# Altium schematic sheet XMC1404KEY4c.SchDoc(XMC1404KEY4c)
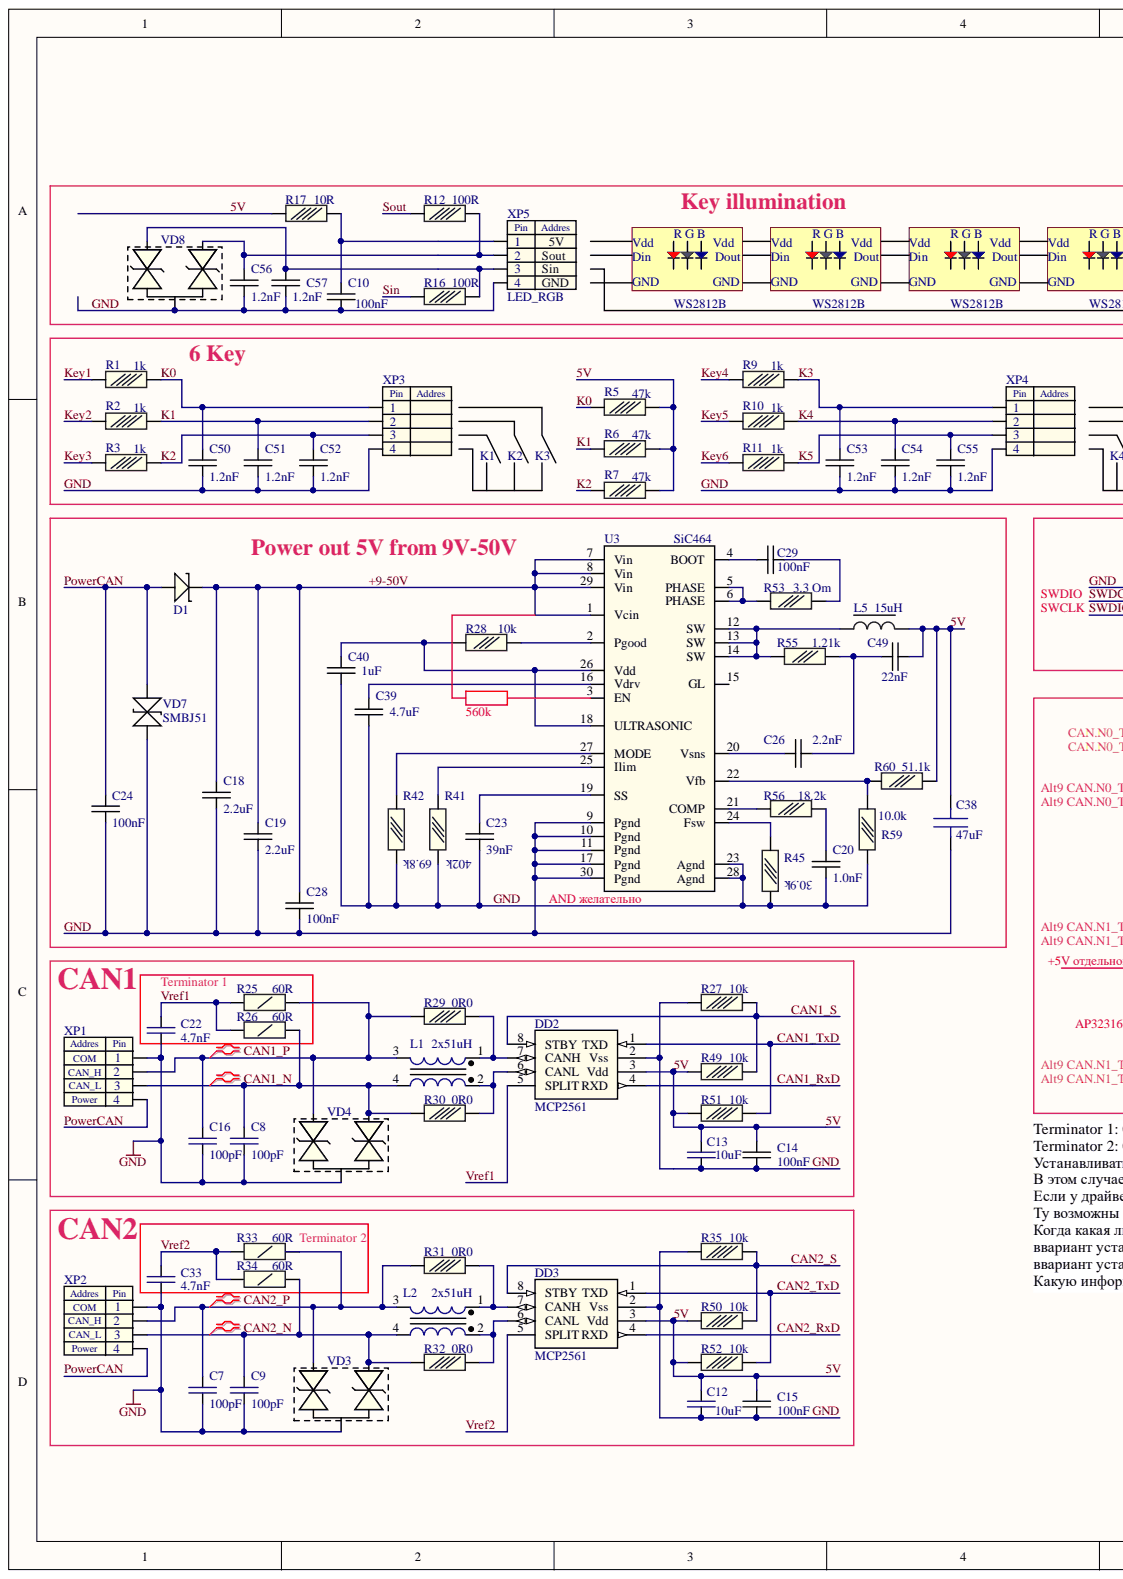
[diagram p0: XMC1404KEY4c.SchDoc, left]
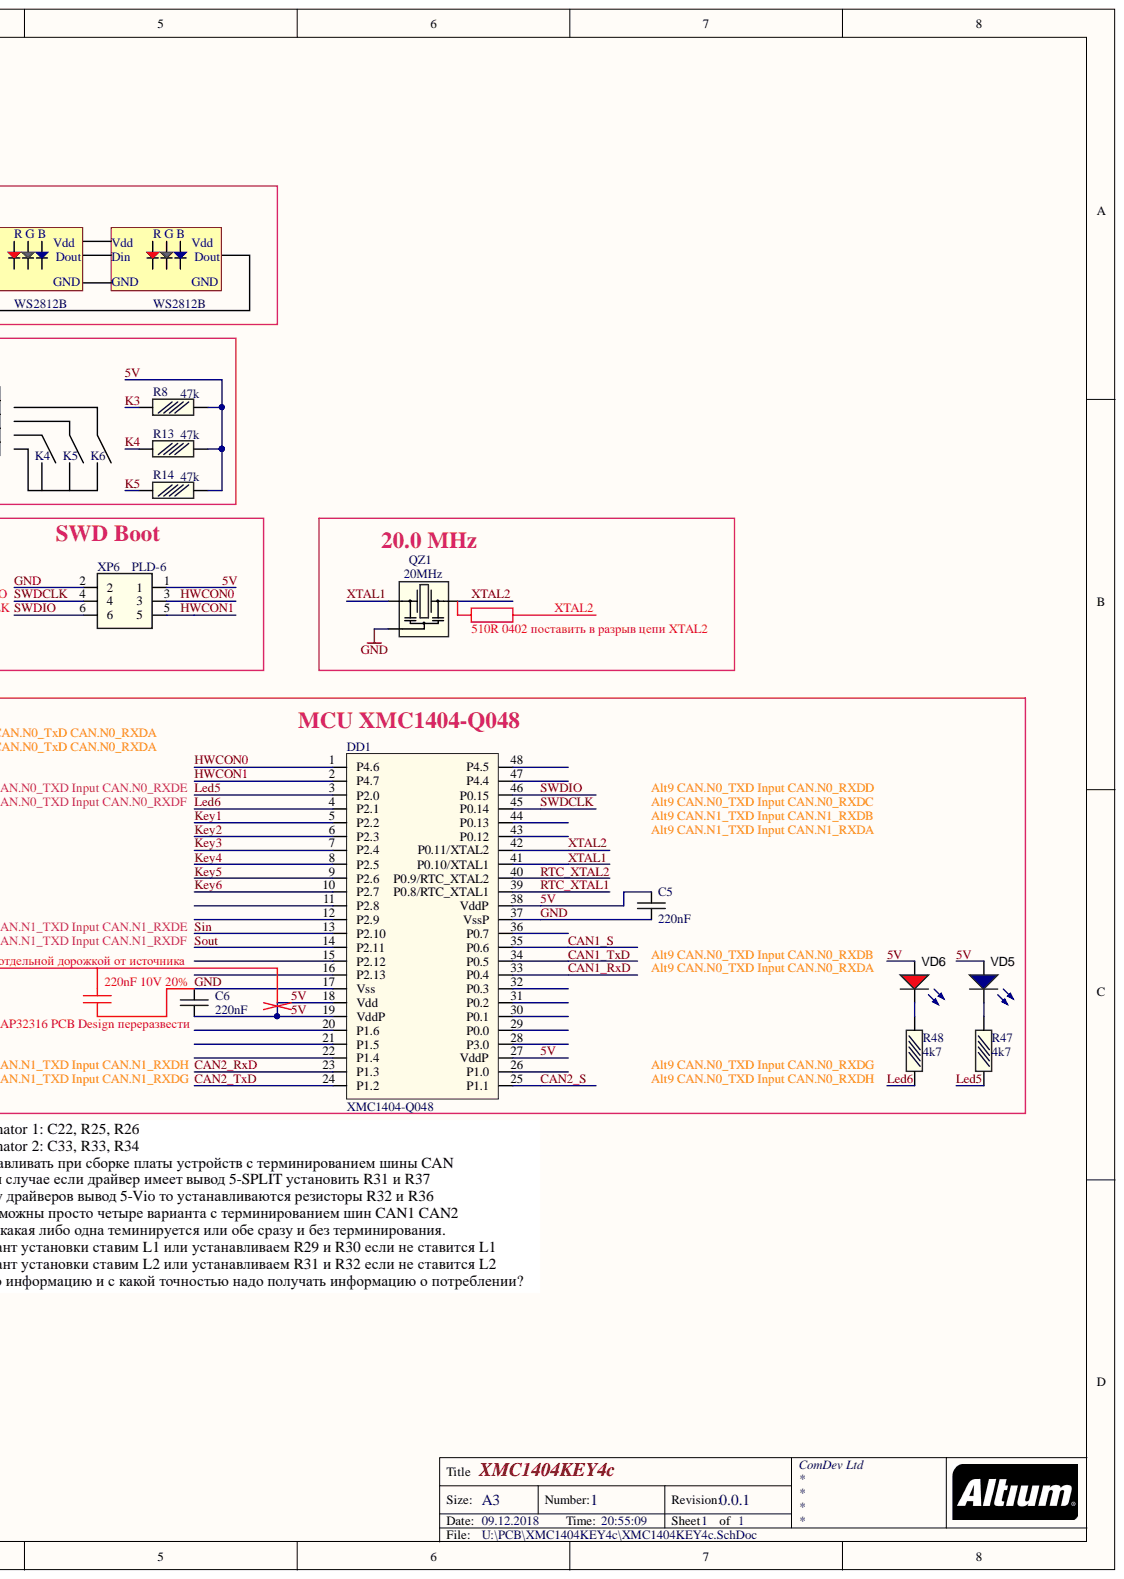
[diagram p1: XMC1404KEY4c.SchDoc, right]

## Parts
C5  220nF  [C0402]
C6  220nF  [C0402]
C7  100pF  [C0603]
C8  100pF  [C0603]
C9  100pF  [C0603]
C10  100nF  [C0402]
C12  10uF  [C0603]
C13  10uF  [C0603]
C14  100nF  [C0402]
C15  100nF  [C0402]
C16  100pF  [C0603]
C18  2.2uF  [C3225 EIA-CC1210]
C19  2.2uF  [C3225 EIA-CC1210]
C20  1.0nF  [C0603]
C22  4.7nF  [C0603]
C23  39nF  [C0603]
C24  100nF  [C0805]
C26  2.2nF  [C0603]
C28  100nF  [C0805]
C29  100nF  [C0603]
C33  4.7nF  [C0603]
C38  47uF  [1210_280]
C39  4.7uF  [C0603]
C40  1uF  [C0603]
C49  22nF  [C0603]
C50  1.2nF  [C0402]
C51  1.2nF  [C0402]
C52  1.2nF  [C0402]
C53  1.2nF  [C0402]
C54  1.2nF  [C0402]
C55  1.2nF  [C0402]
C56  1.2nF  [C0402]
C57  1.2nF  [C0402]
D1  MBR0580S1  [SOD-123 - MBR0520T]  (value not drawn)
DD1  XMC1404-Q048  [PG-VQFN-48-73-XMC1404-Q048]
DD2  MCP2561  [8DFN_MCP2561]
DD3  MCP2561  [8DFN_MCP2561]
L1  2x51uH  [WE-SLM-2x51]
L2  2x51uH  [WE-SLM-2x51]
L5  15uH  [IHLP3232DZER150M11]
QZ1  20MHz  [CSTNE20M0V53C000R0]
R1  1k  [R0402]
R2  1k  [R0402]
R3  1k  [R0402]
R5  47k  [R0402]
R6  47k  [R0402]
R7  47k  [R0402]
R8  47k  [R0402]
R9  1k  [R0402]
R10  1k  [R0402]
R11  1k  [R0402]
R12  100R  [R0402]
R13  47k  [R0402]
R14  47k  [R0402]
R16  100R  [R0402]
R17  10R  [R0402]
R25  60R  [1206]
R26  60R  [1206]
R27  10k  [R0402]
R28  10k  [R0603H-103]
R29  0R0  [R0402]
R30  0R0  [R0402]
R31  0R0  [R0402]
R32  0R0  [R0402]
R33  60R  [1206]
R34  60R  [1206]
R35  10k  [R0402]
R41  402k  [R0603H-470]
R42  69.8k  [R0603H-683]
R45  30.9k  [R0603H-2612]
R47  4k7  [R0402]
R48  4k7  [R0402]
R49  10k  [R0402]
R50  10k  [R0402]
R51  10k  [R0402]
R52  10k  [R0402]
R53  3.3 Om  [R0603H-104]
R55  1.21k  [R0603H-2612]
R56  18.2k  [R0603H-2612]
R59  10.0k  [R0603H-5622]
R60  51.1k  [R0603H-5622]
U3  SiC464  [SiC464_MLP55-27L]
VD3  NUP2105L  [SOT-23]  (value not drawn)
VD4  NUP2105L  [SOT-23]  (value not drawn)
VD5  Blue  [Led0603Blue]  (value not drawn)
VD6  Red  [Led0603Red]  (value not drawn)
VD7  SMBJ51  [SMBJ51-DO-214AA]
VD8  NUP2105L  [SOT-23]  (value not drawn)
XP1  PTSM 0,5/ 4-2,5-V THR R44  [PTSM 0,5/ 4-2,5-H THR R32]  (value not drawn)
XP2  PTSM 0,5/ 4-2,5-V THR R44  [PTSM 0,5/ 4-2,5-H THR R32]  (value not drawn)
XP3  PTSM 0,5/ 4-2,5-V THR R44  [PTSM 0,5/ 4-2,5-H THR R32]  (value not drawn)
XP4  PTSM 0,5/ 4-2,5-V THR R44  [PTSM 0,5/ 4-2,5-H THR R32]  (value not drawn)
XP5  PTSM 0,5/ 4-2,5-V THR R44  [PTSM 0,5/ 4-2,5-H THR R32]  (value not drawn)
XP6  PLD-6  [PBD1.27-6-smd]

## Nets
5V: C5.2 C6.1 C12.2 C13.2 C14.2 C15.2 C38.1 C49.1 DD1.18(Vdd) DD1.19(VddP) DD1.27(VddP) DD1.38(VddP) DD2.3(Vdd) DD3.3(Vdd) L5.2 R5.1 R6.1 R7.1 R8.1 R13.1 R14.1 R17.2 R49.1 R50.1 R51.1 R52.1 R60.2 VD5.1 VD6.1 XP6.1
+9-50V: C18.1 C19.1 C28.2 D1.1 U3.1(Vcin) U3.7(Vin) U3.8(Vin) U3.29(Vin)
CAN1_N: C8.2 L1.4 R26.1 R30.1 VD4.2 XP1.3
CAN1_P: C16.2 L1.3 R25.1 R29.1 VD4.1 XP1.2
CAN1_RxD: DD1.33(P0.4) DD2.4(RXD)
CAN1_S: DD1.35(P0.6) DD2.8(STBY) R27.2 R49.2
CAN1_TxD: DD1.34(P0.5) DD2.1(TXD) R51.2
CAN2_N: C9.2 L2.4 R32.1 R34.1 VD3.2 XP2.3
CAN2_P: C7.2 L2.3 R31.1 R33.1 VD3.1 XP2.2
CAN2_RxD: DD1.23(P1.3) DD3.4(RXD)
CAN2_S: DD1.25(P1.1) DD3.8(STBY) R35.2 R50.2
CAN2_TxD: DD1.24(P1.2) DD3.1(TXD) R52.2
GND: C5.1 C6.2 C7.1 C8.1 C9.1 C10.1 C12.1 C13.1 C14.1 C15.1 C16.1 C18.2 C19.2 C20.2 C22.1 C23.1 C24.1 C28.1 C33.1 C38.2 C39.2 C40.2 C50.1 C51.1 C52.1 C53.1 C54.1 C55.1 C56.1 C57.1 DD1.17(Vss) DD1.37(VssP) DD2.2(Vss) DD3.2(Vss) QZ1.2 R27.1 R35.1 R41.1 R42.1 R45.1 R59.2 U3.9(Pgnd) U3.10(Pgnd) U3.11(Pgnd) U3.17(Pgnd) U3.23(Agnd) U3.28(Agnd) U3.30(Pgnd) VD3.3 VD4.3 VD7.1 VD8.3 XP1.1 XP2.1 XP3.4 XP4.4 XP5.4 XP6.2
HWCON0: DD1.1(P4.6) XP6.3
HWCON1: DD1.2(P4.7) XP6.5
K0: C50.2 R1.1 R5.2 XP3.1
K1: C51.2 R2.1 R6.2 XP3.2
K2: C52.2 R3.1 R7.2 XP3.3
K3: C53.2 R8.2 R9.1 XP4.1
K4: C54.2 R10.1 R13.2 XP4.2
K5: C55.2 R11.1 R14.2 XP4.3
Key1: DD1.5(P2.2) R1.2
Key2: DD1.6(P2.3) R2.2
Key3: DD1.7(P2.4) R3.2
Key4: DD1.8(P2.5) R9.2
Key5: DD1.9(P2.6) R10.2
Key6: DD1.10(P2.7) R11.2
Led5: DD1.3(P2.0) R47.1
Led6: DD1.4(P2.1) R48.1
PowerCAN: C24.2 D1.2 VD7.2 XP1.4 XP2.4
RTC_XTAL1: DD1.39(P0.8/RTC_XTAL1)
RTC_XTAL2: DD1.40(P0.9/RTC_XTAL2)
Sin: DD1.13(P2.10) R16.2
Sout: DD1.14(P2.11) R12.2
SWDCLK: DD1.45(P0.14) XP6.4
SWDIO: DD1.46(P0.15) XP6.6
Vref1: C22.2 DD2.5(SPLIT) R25.2 R26.2
Vref2: C33.2 DD3.5(SPLIT) R33.2 R34.2
XTAL1: DD1.41(P0.10/XTAL1) QZ1.1
XTAL2: DD1.42(P0.11/XTAL2) QZ1.3
NetC10_2: C10.2 R17.1 XP5.1
NetC20_1: C20.1 R56.1
NetC23_2: C23.2 U3.19(SS)
NetC26_1: C26.1 C49.2 R55.1
NetC26_2: C26.2 U3.20(Vsns)
NetC29_1: C29.1 R53.2
NetC29_2: C29.2 U3.4(BOOT)
NetC39_1: C39.1 U3.16(Vdrv)
NetC40_1: C40.1 R28.1 U3.18(ULTRASONIC) U3.26(Vdd)
NetC56_2: C56.2 R12.1 VD8.2 XP5.2
NetC57_2: C57.2 R16.1 VD8.1 XP5.3
NetDD2_6: DD2.6(CANL) L1.2 R30.2
NetDD2_7: DD2.7(CANH) L1.1 R29.2
NetDD3_6: DD3.6(CANL) L2.2 R32.2
NetDD3_7: DD3.7(CANH) L2.1 R31.2
NetL5_1: L5.1 R55.2 U3.12(SW) U3.13(SW) U3.14(SW)
NetR28_2: R28.2 U3.2(Pgood)
NetR41_2: R41.2 U3.25(Ilim)
NetR42_2: R42.2 U3.27(MODE)
NetR45_2: R45.2 U3.24(Fsw)
NetR47_2: R47.2 VD5.2
NetR48_2: R48.2 VD6.2
NetR53_1: R53.1 U3.5(PHASE) U3.6(PHASE)
NetR56_2: R56.2 U3.21(COMP)
NetR59_1: R59.1 R60.1 U3.22(Vfb)

Not connected: DD1 11(P2.8) 12(P2.9) 15(P2.12) 16(P2.13) 20(P1.6) 21(P1.5) 22(P1.4) 26(P1.0) 28(P3.0) 29(P0.0) 30(P0.1) 31(P0.2) 32(P0.3) 36(P0.7) 43(P0.12) 44(P0.13) 47(P4.4) 48(P4.5); U3 3(EN) 15(GL)

## Library data of the parts
C5 C6 = C0402: 220nF 6.3V 10% 0603 X7R; L_DB: ???; ValueSCH: 220nF; NoteSCH: X7R; Library Name: Capacitors.SchLib; ComponentLink2Description: Datasheet; Component Kind: Standard; ComponentLink2URL: C:\Project\Doc\Конденсаторы\Керамика\MLCC__Chip__X7R.pdf
C7-C9 C16 = C0603: CAP CER 100PF 25V X7R 0603; Manufacturer Part Number: 06033C101KAT2A; Manufacturer Name: AVX Corporation; Value: 100pF/25V/X7R/10%/SMD/0603; Tolerance: ±10%; Voltage - Rated: 25V; ValueSch: 100pF; Thickness (Max): 0.035" (0.90mm); Temperature Coefficient: X7R; Supplier Part Number 1: 478-7899-2-ND; Supplier 1: Digi-Key; Stock 1: 24000; Size / Dimension: 0.063" L x 0.032" W (1.60mm x 0.81mm); RoHS: RoHS Compliant; Ratings: -; Pricing 1: 4000=0.0418, 8000=0.03971, 12000=0.03762 (USD); Part Status: Active; Packaging: Tape & Reel (TR); Package / Case: 0603 (1608 Metric); Operating Temperature: -55°C ~ 125°C; Mounting Type: Surface Mount, MLCC; Library Name: Capacitors.SchLib; Lead Style: -; Lead Spacing: -; Height - Seated (Max): -; Features: -; Failure Rate: -; ComponentLink3URL: http://datasheets.avx.com/X7RDielectric.pdf; ComponentLink3Description: Datasheet1; ComponentLink2URL: http://analytics.supplyframe.com/trackingservlet/track/?r=gPVv3S5fz9WS0muSSENj7lwoQ-bwq6U_kTVAS-E-4hFfgjTGNLzICkif58pW5xVzodrlN_TFWDfT-ihNJt1bYujWQ1ervE1ODJn9hr95yS1WEK84krV-DVCh3Pjis-mDRI3V01RDXCSfn3TgClWxILI83iyMk702gQDWEiRNL7hjlIlwe7usE8k12gPZrRSiqHgTvvD3EcVIugmCVY18oj7b5cljMpSJ7IWvPMjQLzsYV9TI6TLqAWqhYn857NWra7I7WIt4LPWFkkh1MoSB8QsQPueJ7cWwSbt4t62NzL017uztGtoLOf0FGsKGNBWESZWOcdJ6202ZSChtzbyJ3aCGV0V0vDtxPirZU9HbAKCtZHougte4xZ9MMqby1oitbLO64CniNyiwkJNtVPIyrynnG8uFkhA482lKC76bORkhaPKnCl4U_69JWakdAr7PCLCuSBhWj0c3rGkDodRwls4EObJQnz4gUzrAOT7V_rgoM_Io8BWJQSzhMwh6iWlDz_NNcRo2wvNcatip_pJJ4vSL8TnRa_NPsW0ZvwBjV9dIyk2oNY8-7e27U9VPQ8CszMTe1mfDoxXcIQrWsehP0w; ComponentLink2Description: Datasheet; ComponentLink1URL: https://www.arrow.com/en/manufacturers/tdk; ComponentLink1Description: Manufacturer Link; Component Kind: Standard; Category: Capacitors; Applications: General Purpose
C10 C14 C15 = C0402: 100nF 10V 10% 0603 X7R; L_DB: ???; ValueSCH: 100nF; NoteSCH: X7R; Library Name: Capacitors.SchLib; ComponentLink2Description: Datasheet; Component Kind: Standard; ComponentLink2URL: C:\Project\Doc\Конденсаторы\Керамика\MLCC__Chip__X7R.pdf
C12 C13 = C0603: CAP CER 10UF 6.3V X5R 0603; Manufacturer Part Number: CC0603MRX5R5BB106; Manufacturer Name: Yageo; Value: 10uF/6.3V/20%/X5R/C0603; Tolerance: ±20%; Voltage - Rated: 6.3V; ValueSCH: 10uF; Thickness (Max): 0.035" (0.90mm); Temperature Coefficient: X5R; Supplier Part Number 1: 399-5504-2-ND; Supplier 1: Digi-Key; Stock 1: 1768000; Size / Dimension: 0.063" L x 0.032" W (1.60mm x 0.80mm); RoHS: RoHS Compliant; Ratings: -; Pricing 1: 4000=0.08041, 8000=0.07568, 12000=0.07332 (USD); Part Status: Active; Packaging: Tape & Reel (TR); Package / Case: 0603 (1608 Metric); Operating Temperature: -55°C ~ 85°C; Mounting Type: Surface Mount, MLCC; Library Name: Capacitors.SchLib; Lead Style: -; Lead Spacing: -; Height - Seated (Max): -; Features: -; Failure Rate: -; ComponentLink2URL: http://www.kemet.com/docfinder?Partnumber=C0603C106M9PACTU; ComponentLink2Description: Link; ComponentLink1URL: http://datasheets.avx.com/Y5V.pdf; ComponentLink1Description: Datasheet; Component Kind: Standard; Category: Capacitors; Applications: General Purpose
C18 C19 = C1210: 2.2uF 1210 X7R 100V; Part Number: C1210S225M1RA; L_DB: ???; ValueSCH: 2.2uF; Value: 2.2uF 1210 X7R 100V; Library Name: Capacitors.SchLib; ComponentLink2Description: Datasheet; Component Kind: Standard; ComponentLink2URL: C:\Project\Doc\Конденсаторы\Керамика\MLCC__Chip__X7R.pdf
C20 = C0603: CAP CER 1.0nF 50V X7R 0603 1.0 mOhm; Manufacturer Name: GRM188R71H102KA01D; Value: CAP CER 2.0nF 50V X7R 0603 1.0 mOhm; ValueSch: 1.0nF; Library Name: Capacitors.SchLib; ComponentLink2Description: Datasheet; ComponentLink1Description: Manufacturer Link; Component Kind: Standard
C22 C33 = C0603: CAP CER 4700PF 50V C0G 0603; Manufacturer Part Number: CGA3E2C0G1H472J080AA; Manufacturer Name: TDK; Manufacturer: TDK Corporation; Tolerance: ±5%; Temperature Coefficient: C0G, NP0; Voltage - Rated: 50V; ValueSch: 4.7nF; Value: CAP CER 4700PF 50V C0G 0603; Thickness (Max): 0.035" (0.90mm); Supplier Part Number 1: 445-6912-2-ND; Supplier 1: Digi-Key; Stock 1: 4000; Size / Dimension: 0.063" L x 0.032" W (1.60mm x 0.80mm); RoHS: RoHS Compliant; Ratings: AEC-Q200; Pricing 1: 4000=0.05088, 8000=0.04813, 12000=0.04565 (USD); Part Status: Active; Packaging: Tape & Reel (TR); Package / Case: 0603 (1608 Metric); Operating Temperature: -55°C ~ 125°C; Mounting Type: Surface Mount, MLCC; Library Name: Capacitors.SchLib; ComponentLink3URL: https://product.tdk.com/info/en/catalog/datasheets/mlcc_automotive_general_en.pdf; ComponentLink3Description: Datasheet2; ComponentLink2URL: https://www.arrow.com/en/manufacturers/tdk; ComponentLink2Description: Datasheet1; Component Kind: Standard; Category: Capacitors; Applications: Automotive
C23 = C0603: 39nF 50V COG 0603; Value: 39nF 50V COG 0603 +-0.1pF; ValueSch: 39nF; Library Name: Capacitors.SchLib; ComponentLink2Description: Datasheet; ComponentLink1Description: Manufacturer Link; Component Kind: Standard
C24 C28 = C0805: 100nF X7R 100V 0805 20%; Part Nomber: VJ0805Y104MXB; L_DB: ???; ValueSCH: 100nF; Value: 100nF X7R 100V 0805 20%; PCB3D: 0805; NoteSCH: X7R; Library Name: Capacitors.SchLib; ComponentLink2Description: Datasheet; Component Kind: Standard; ComponentLink2URL: C:\Project\Doc\Конденсаторы\Керамика\MLCC__Chip__X7R.pdf
C26 = C0603: CAP CER 2.2nF 50V X7R 0603 1.0 mOhm; Manufacturer Name: GRM188R71H222KA01D; ValueSch: 2.2nF; Value: CAP CER 2.2nF 50V X7R 0603 1.0 mOhm; Library Name: Capacitors.SchLib; ComponentLink2Description: Datasheet; ComponentLink1Description: Manufacturer Link; Component Kind: Standard
C29 = C0603: 0.1uF 25V BX 10% 0603 Ceramic; Manufacturer Part Number: 03028-BX104ZKZ; ValueSch: 100nF; Value: 0.1uF 25V BX 10% 0603 Ceramic; Library Name: Capacitors.SchLib; ComponentLink2Description: Datasheet; ComponentLink1Description: Manufacturer Link; Component Kind: Standard
C38 = Cout_12V: CAP CER 47UF 16V X5R 1210; Manufacturer Part Number: GRM32ER61C476ME15L; Manufacturer Name: MuRata; Manufacturer: Murata Electronics North America; Tolerance: ±20%; Voltage - Rated: 16V; ValueSCH: 47uF; Value: CAP CER 47UF 16V X5R 1210; Thickness (Max): 0.106" (2.70mm); Temperature Coefficient: X5R; Supplier Part Number 2: 490-8355-2-ND; Supplier Part Number 1: 490-3888-2-ND; Supplier 2: Digi-Key; Supplier 1: Digi-Key; Stock 2: 60000; Stock 1: 418000; Size / Dimension: 0.126" L x 0.098" W (3.20mm x 2.50mm); RoHS: RoHS Compliant; Ratings: -; Pricing 2: 4000=0.231, 8000=0.22275, 12000=0.21945 (USD); Pricing 1: 1000=0.264, 2000=0.2475 (USD); Part Status: Active; Packaging: Tape & Reel (TR); PackageReference: GRM32ER61C476ME15L; Package / Case: 1210 (3225 Metric); Operating Temperature: -55°C ~ 85°C; Mounting Type: Surface Mount, MLCC; Lead Style: -; Lead Spacing: -; Height - Seated (Max): -; Features: -; ComponentLink1URL: http://www.murata.com/~/media/webrenewal/support/library/catalog/products/capacitor/mlcc/c02e.pdf; ComponentLink1Description: Datasheet; Component Kind: Standard; Category: Capacitors; Applications: General Purpose; Library Name: Vin50Vout12V_2A.SCHLIB
C39 = C0603: 4.7uF 20% X5R 16V 0603; Part Nomber: VJ0603G475MXJ; ValueSch: 4.7uF; Value: 4.7uF 20% X5R 16V 0603; Library Name: Capacitors.SchLib; ComponentLink2Description: Datasheet; ComponentLink1Description: Manufacturer Link; Component Kind: Standard
C40 = C0603: 1UF 20% 0603 Y5V 16V; Manufacturer Part Number: VJ0603V105MXA; Value: 1UF 20% 0603 Y5V 16V Ceramic; ValueSch: 1uF; Library Name: Capacitors.SchLib; ComponentLink2Description: Datasheet; ComponentLink1Description: Manufacturer Link; Component Kind: Standard
C49 = C0603: CAP CER 22nF 50V X7R 0603 1.0 mOhm; Manufacturer Name: GRM188R71H202KA01D; ValueSch: 22nF; Value: CAP CER 22nF 50V X7R 0603 1.0 mOhm; Library Name: Capacitors.SchLib; ComponentLink2Description: Datasheet; ComponentLink1Description: Manufacturer Link; Component Kind: Standard
C50-C57 = C0402: Value: 1.2nF 0402 20% COG; NoteSCH: COG; L_DB: ???; ValueSCH: 1.2nF; Library Name: Capacitors.SchLib; ComponentLink2Description: Datasheet; Component Kind: Standard; ComponentLink2URL: C:\Project\Doc\Конденсаторы\Керамика\MLCC__Chip__X7R.pdf
D1 = MBR0580S1: Диод SMD MBR0580S1 DIODS; L_DB: ???; ValueSCH: MBR0580S1; Library Name: Diodes.SchLib; Component Kind: Standard
DD1 = XMC1404-Q048: XMC1404-Q048X0200; Flash Size: 200k; Value_SCH: XMC1404-Q048; Library Name: MCU.SchLib; Device Type: XMC1404-Q048X0200; ComponentLink2Description: Datasheet; Component Kind: Standard; ComponentLink2URL: C:\Project\Doc\Maxim\HART\DS8500.pdf
DD2 DD3 = MCP2561: High speed CAN transceiver with SPLIT and 5V microcontroller interface; Library Name: Driver.SchLib; Component Kind: Standard
L1 L2 = WE-SLM-2x51: SMD Common Mode Line Filter; L_DB: ???; ValueSCH: 2x51uH; Library Name: Inductor.SchLib; ComponentLink2Description: Datasheet; Component Kind: Standard; ComponentLink2URL: C:\Project\Doc\Индуктивности\SMLP5001.pdf
L5 = IHLP3232DZER150M11: Rdcmax: 65.3mOhm; Rdc: 61.0mOhm; L_DB: ???; ValueSCH: 15uH; NoteSCH: 15uH; Library Name: Inductor.SchLib; Description: IHLP3232DZER150M11; ComponentLink2Description: Datasheet; Component Kind: Standard; ComponentLink2URL: C:\Project\Doc\Индуктивности\slf6028.pdf
QZ1 = CSTNE20M0V53C000R0: CS TNE 20.00MHz Built in Caps 15 pF; Value: 20.00MHz 0.15% with C 15pF; NoteSCH: CSTNE; Mark: Not Mark; L_DB: ???; ValueSCH: 20MHz; Package: ECS; ComponentLink2Description: Datasheet; Component Kind: Standard; Library Name: Crystal Units.SchLib; ComponentLink2URL: C:\Project\Doc\Кварцевые резонаторы\KX-327XS.pdf
R1-R3 R9-R11 = R0402H: 1k 5% R0402; ValueSCH: 1k; Value: 1k 5% R0402; PCB3D: R0402H; Library Name: Resistors.SchLib; Component Kind: Standard
R5-R8 R13 R14 = R0402H: 47k 5% R0402; ValueSCH: 47k; Value: 47k 5% R0402; PCB3D: R0402H; Library Name: Resistors.SchLib; Component Kind: Standard
R12 R16 = R0402H: 100R 5% R0402; ValueSCH: 100R; Value: 100R 5% R0402; PCB3D: R0402H; Library Name: Resistors.SchLib; Component Kind: Standard
R17 = R0402H: 10R 5% R0402; ValueSCH: 10R; Value: 10R 5% R0402; PCB3D: R0402H; Library Name: Resistors.SchLib; Component Kind: Standard
R25 R26 R33 R34 = R1206: RES SMD 60 OHM 5% 1/2W 1206; Value: 60R/5%/1206/0.5W; L_DB: ???; ValueSCH: 60R; PCB3D: 1206; Library Name: Resistors.SchLib; ComponentLink2Description: Datasheet; Component Kind: Standard; ComponentLink2URL: C:\Project\Doc\Резисторы\Pu-RC1206_51_PbFree_L_2-1.pdf
R27 R35 R49-R52 = R0402H: RES SMD 10KOHM 5% 1/16W 0402; Value: 10k/5%/0402/0.125W; ValueSCH: 10k; PCB3D: R0402H; Library Name: Resistors.SchLib; Component Kind: Standard
R28 = R0603: RES SMD 10K OHM 5% 1/4W 0603; Manufacturer Part Number: RC0603JR-07103RL; Manufacturer Name: Yageo; Value: 10k/5%/0603/0.25W; L_DB: ???; ValueSCH: 10k; Library Name: Resistors.SchLib; ComponentLink2URL: https://www.digikey.com/product-detail/en/yageo/RC0603JR-074K7L/311-4.7KGRTR-ND/726785; ComponentLink2Description: Datasheet; ComponentLink1URL: https://www.digikey.com/en/supplier-centers/y/yageo; ComponentLink1Description: Manufacturer Link; Component Kind: Standard
R29-R32 = R0402H: RES SMD 0 OHM 5% 1/16W 0402; Value: 0R0/5%/0402/0.125W; ValueSCH: 0R0; PCB3D: R0402H; Library Name: Resistors.SchLib; Component Kind: Standard
R41 = R0603: RES SMD 402k 1% 1/10W 0603; Manufacturer Part Number: CMF55603K00BEEA70; Manufacturer Name: Yageo; Manufacturer: Vishay Dale; Velue: 402k/1%/0.1W/0603; Temperature Coefficient: ±100ppm/°C; Power (Watts): 0.125W, 1/10W; L_DB: ???; ValueSCH: 402k; Tolerance: ±1%; Supplier Part Number 1: CMF55603K00BEEA70; Supplier Device Package: 0603; Supplier 1: Digi-Key; Stock 1: 0; Size / Dimension: 0.039" L x 0.020" W (1.00mm x 0.50mm); RoHS: RoHS Compliant; Pricing 1: 10000=0.0038, 30000=0.00334, 50000=0.00306, 100000=0.003 (USD); Part Status: Active; Packaging: Tape & Reel (TR); Package / Case: 0603; Operating Temperature: -55°C ~ 155°C; Library Name: Resistors.SchLib; Height - Seated (Max): 0.016" (0.40mm); Features: Automotive AEC-Q200; Failure Rate: -; Composition: Thick Film; ComponentLink3URL: http://www.vishay.com/docs/20035/dcrcwe3.pdf; ComponentLink3Description: http://www.vishay.com/docs/20035/dcrcwe3.pdf; ComponentLink2URL: https://www.digikey.com/product-detail/en/yageo/RC0603FR-0726K1L/311-26.1KHRTR-ND/727092; ComponentLink2Description: Datasheet; ComponentLink1URL: https://www.digikey.com/en/supplier-centers/y/yageo; ComponentLink1Description: Manufacturer Link; Component Kind: Standard; Category: Resistors
R42 = R0603: RES SMD 69.8k 1% 1/10W 0603; Manufacturer Part Number: CCF-50 6982 F T1 R36; Manufacturer Name: Yageo; Manufacturer: Vishay Dale; Velue: 69.8k/1%/0.1W/0603; Temperature Coefficient: ±100ppm/°C; Power (Watts): 0.125W, 1/10W; L_DB: ???; ValueSCH: 69.8k; Tolerance: ±1%; Supplier Part Number 1: 541-26.1KLTR-ND; Supplier Device Package: 0603; Supplier 1: Digi-Key; Stock 1: 0; Size / Dimension: 0.039" L x 0.020" W (1.00mm x 0.50mm); RoHS: RoHS Compliant; Pricing 1: 10000=0.0038, 30000=0.00334, 50000=0.00306, 100000=0.003 (USD); Part Status: Active; Packaging: Tape & Reel (TR); Package / Case: 0603; Operating Temperature: -55°C ~ 155°C; Library Name: Resistors.SchLib; Height - Seated (Max): 0.016" (0.40mm); Features: Automotive AEC-Q200; Failure Rate: -; Composition: Thick Film; ComponentLink3URL: http://www.vishay.com/docs/20035/dcrcwe3.pdf; ComponentLink3Description: http://www.vishay.com/docs/20035/dcrcwe3.pdf; ComponentLink2URL: https://www.digikey.com/product-detail/en/yageo/RC0603FR-0769K8L/311-69.8KHRTR-ND/727092; ComponentLink2Description: Datasheet; ComponentLink1URL: https://www.digikey.com/en/supplier-centers/y/yageo; ComponentLink1Description: Manufacturer Link; Component Kind: Standard; Category: Resistors
R45 = R0603: RES SMD 30.9kOHM 1% 1/10W 0603; Manufacturer Part Number: CRCW06033094RFKED; Manufacturer Name: Yageo; Manufacturer: Vishay Dale; Velue: 30.9k/1%/0.1W/0603; Temperature Coefficient: ±100ppm/°C; Power (Watts): 0.125W, 1/10W; L_DB: ???; ValueSCH: 30.9k; Tolerance: ±1%; Supplier Part Number 1: 541-30.9KLTR-ND; Supplier Device Package: 0603; Supplier 1: Digi-Key; Stock 1: 0; Size / Dimension: 0.039" L x 0.020" W (1.00mm x 0.50mm); RoHS: RoHS Compliant; Pricing 1: 10000=0.0038, 30000=0.00334, 50000=0.00306, 100000=0.003 (USD); Part Status: Active; Packaging: Tape & Reel (TR); Package / Case: 0603; Operating Temperature: -55°C ~ 155°C; Library Name: Resistors.SchLib; Height - Seated (Max): 0.016" (0.40mm); Features: Automotive AEC-Q200; Failure Rate: -; Composition: Thick Film; ComponentLink3URL: http://www.vishay.com/docs/20035/dcrcwe3.pdf; ComponentLink3Description: http://www.vishay.com/docs/20035/dcrcwe3.pdf; ComponentLink2URL: https://www.digikey.com/product-detail/en/yageo/RC0603FR-0744K2L/311-44.2KHRTR-ND/727092; ComponentLink2Description: Datasheet; ComponentLink1URL: https://www.digikey.com/en/supplier-centers/y/yageo; ComponentLink1Description: Manufacturer Link; Component Kind: Standard; Category: Resistors
R47 R48 = R0402H: RES SMD 4.7KOHM 5% 1/16W 0402; Value: 4k7/5%/0402/0.125W; ValueSCH: 4k7; PCB3D: R0402H; Library Name: Resistors.SchLib; Component Kind: Standard
R53 = R0603: RES SMD 3.3 OHM 5% 1/10W 0603; Value: 3.3Om/5%/0603/0.125W; L_DB: ???; ValueSCH: 3.3 Om; NoteSCH: RES SMD 3.3 OHM 5% 1/10W 0603; Library Name: Resistors.SchLib; ComponentLink2Description: Datasheet; ComponentLink1Description: Manufacturer Link; Component Kind: Standard
R55 = R0603: RES SMD 1.21kOHM 1% 1/10W 0603; Manufacturer Part Number: CRCW06031.21kRFKED; Manufacturer Name: Yageo; Manufacturer: Vishay Dale; Velue: 1.21k/1%/0.1W/0603; Temperature Coefficient: ±100ppm/°C; Power (Watts): 0.125W, 1/10W; L_DB: ???; ValueSCH: 1.21k; Tolerance: ±1%; Supplier Part Number 1: 541-1.21KLTR-ND; Supplier Device Package: 0603; Supplier 1: Digi-Key; Stock 1: 0; Size / Dimension: 0.039" L x 0.020" W (1.00mm x 0.50mm); RoHS: RoHS Compliant; Pricing 1: 10000=0.0038, 30000=0.00334, 50000=0.00306, 100000=0.003 (USD); Part Status: Active; Packaging: Tape & Reel (TR); Package / Case: 0603; Operating Temperature: -55°C ~ 155°C; Library Name: Resistors.SchLib; Height - Seated (Max): 0.016" (0.40mm); Features: Automotive AEC-Q200; Failure Rate: -; Composition: Thick Film; ComponentLink3URL: http://www.vishay.com/docs/20035/dcrcwe3.pdf; ComponentLink3Description: http://www.vishay.com/docs/20035/dcrcwe3.pdf; ComponentLink2URL: https://www.digikey.com/product-detail/en/yageo/RC0603FR-0726K1L/311-26.1KHRTR-ND/727092; ComponentLink2Description: Datasheet; ComponentLink1URL: https://www.digikey.com/en/supplier-centers/y/yageo; ComponentLink1Description: Manufacturer Link; Component Kind: Standard; Category: Resistors
R56 = R0603: RES SMD 18.2KOHM 1% 1/10W 0603; Manufacturer Part Number: CRCW06031822RFKED; Manufacturer Name: Yageo; Manufacturer: Vishay Dale; Velue: 18.2k/1%/0.1W/0603; Temperature Coefficient: ±100ppm/°C; Power (Watts): 0.125W, 1/10W; L_DB: ???; ValueSCH: 18.2k; Tolerance: ±1%; Supplier Part Number 1: 541-18.2KLTR-ND; Supplier Device Package: 0603; Supplier 1: Digi-Key; Stock 1: 0; Size / Dimension: 0.039" L x 0.020" W (1.00mm x 0.50mm); RoHS: RoHS Compliant; Pricing 1: 10000=0.0038, 30000=0.00334, 50000=0.00306, 100000=0.003 (USD); Part Status: Active; Packaging: Tape & Reel (TR); Package / Case: 0603; Operating Temperature: -55°C ~ 155°C; Library Name: Resistors.SchLib; Height - Seated (Max): 0.016" (0.40mm); Features: Automotive AEC-Q200; Failure Rate: -; Composition: Thick Film; ComponentLink3URL: http://www.vishay.com/docs/20035/dcrcwe3.pdf; ComponentLink3Description: http://www.vishay.com/docs/20035/dcrcwe3.pdf; ComponentLink2URL: https://www.digikey.com/product-detail/en/yageo/RC0603FR-0722K1L/311-22.1KHRTR-ND/727092; ComponentLink2Description: Datasheet; ComponentLink1URL: https://www.digikey.com/en/supplier-centers/y/yageo; ComponentLink1Description: Manufacturer Link; Component Kind: Standard; Category: Resistors
R59 = R0603: RES SMD 10.0k OHM 1% 1/10W 0603; Manufacturer Part Number: RC0603FR-0710K0L; Manufacturer Name: Yageo; Manufacturer: Vishay Dale; Value: 10.0k/1%/0603/0.25W; Temperature Coefficient: ±100ppm/°C; Power (Watts): 0.063W, 1/16W; L_DB: ???; ValueSCH: 10.0k; Tolerance: ±1%; Supplier Part Number 1: 541-147KLCT-ND; Supplier Device Package: 0603; Supplier 1: Digi-Key; Stock 1: 15791; Size / Dimension: 0.039" L x 0.020" W (1.00mm x 0.50mm); RoHS: RoHS Compliant; Pricing 1: 1=0.1, 10=0.037, 100=0.0149, 1000=0.0067, 2500=0.00582, 5000=0.00481 (USD); Part Status: Active; Packaging: Cut Tape (CT); Package / Case: 0603; Operating Temperature: -55°C ~ 155°C; Library Name: Resistors.SchLib; Height - Seated (Max): 0.016" (0.40mm); Features: Automotive AEC-Q200; Failure Rate: -; Composition: Thick Film; ComponentLink3URL: http://www.vishay.com/docs/20035/dcrcwe3.pdf; ComponentLink3Description: http://www.vishay.com/docs/20035/dcrcwe3.pdf; ComponentLink2URL: https://www.digikey.com/product-detail/en/yageo/RC0603FR-07147KL/311-147KHRTR-ND/726951; ComponentLink2Description: Datasheet; ComponentLink1URL: https://www.digikey.com/en/supplier-centers/y/yageo; ComponentLink1Description: Manufacturer Link; Component Kind: Standard; Category: Resistors
R60 = R0603: 51.1k 1% 0603 50ppm; Part Number: 231221515112; L_DB: ???; ValueSCH: 51.1k; Value: 51.1k 1% 0603 50ppm; NoteSCH: 51.1k 1% 0603 50ppm; Manufacturer Part Number: 231221515112; Library Name: Resistors.SchLib; ComponentLink2Description: Datasheet; ComponentLink1Description: Manufacturer Link; Component Kind: Standard
U3 = SiC464: Manufacturer Name: Texas Instruments; Value: Value; PackageReference: SiC464; Manufacturer Part Number: SiC464; Library Name: Power.SchLib; Description: SiC464; Component Kind: Standard
VD3 VD4 VD8 = NUP2105L: NUP2105L 24V 350W protect the CAN  transceiver; Manufacturer Part Number: NUP2105LT1G; Manufacturer Name: ON Semiconductor; PackageMark: 27EM*; ValueSCH: NUP2105L; Value: NUP2105L; Package: SOT-23; MaxParamRange: 24V; Library Name: Diodes.SchLib; Component Kind: Standard; Code_JEDEC: 27EM*
VD5 = Led0603Blue: Ultra lighet PT-1608H183BC Blue InGaN LED; L_DB: ???; ValueSCH: Blue; PackageReference: LED-0; Library Name: LED.SchLib; ComponentLink2Description: Datasheet; Component Kind: Standard; Publisher: Michael; ComponentLink2URL: C:\Project\Doc\Opto\CHIP_LED\HL-PT-1608H184W-444.pdf
VD6 = Led0603Red: Ultra lighet RL-S190SC Super Red InGaN LED; L_DB: ???; ValueSCH: Red; PCB3D: Led0603_RL-S190SC_Red; PackageReference: LED-0; Library Name: LED.SchLib; ComponentLink2Description: Datasheet; Component Kind: Standard; Publisher: Michael; ComponentLink2URL: C:\Project\Doc\Opto\CHIP_LED\HL-PT-1608U4FC-108.pdf
VD7 = SMBJ51: 51V Suppressor 82.4V SMBJ51; Library Name: Diodes.SchLib; Component Kind: Standard
XP1 XP2 = PTSM 0,5 4-2,5-V THR R44_CAN: OrderNo: 1770979; L_DB: ???; ValueSCH: PTSM 0,5/ 4-2,5-V THR R44; Library Name: Connector.SchLib; Description: PTSM 0,5/ 4-2,5-V THR R44; ComponentLink2Description: Datasheet; ComponentLink1URL: http://eshop.phoenixcontact.de/phoenix/treeViewClick.do?UID=1770979; ComponentLink1Description: Manufacturer Link; Component Kind: Standard; ComponentLink2URL: G:\Doc\Connectors\CAN\1770979.pdf
XP3-XP5 = PTSM 0,5 4-2,5-V THR R44: OrderNo: 1770979; L_DB: ???; ValueSCH: PTSM 0,5/ 4-2,5-V THR R44; Library Name: Connector.SchLib; Description: PTSM 0,5/ 4-2,5-V THR R44; ComponentLink2Description: Datasheet; ComponentLink1URL: http://eshop.phoenixcontact.de/phoenix/treeViewClick.do?UID=1770979; ComponentLink1Description: Manufacturer Link; Component Kind: Standard; ComponentLink2URL: G:\Doc\Connectors\CAN\1770979.pdf
XP6 = PLD-6-1.27: 6PIN Connector Assignments pich 1.27; Evaluate_Board: Next01; Library Name: Connector.SchLib; Component Kind: Standard
